# Altium schematic sheet MOS.SchDoc
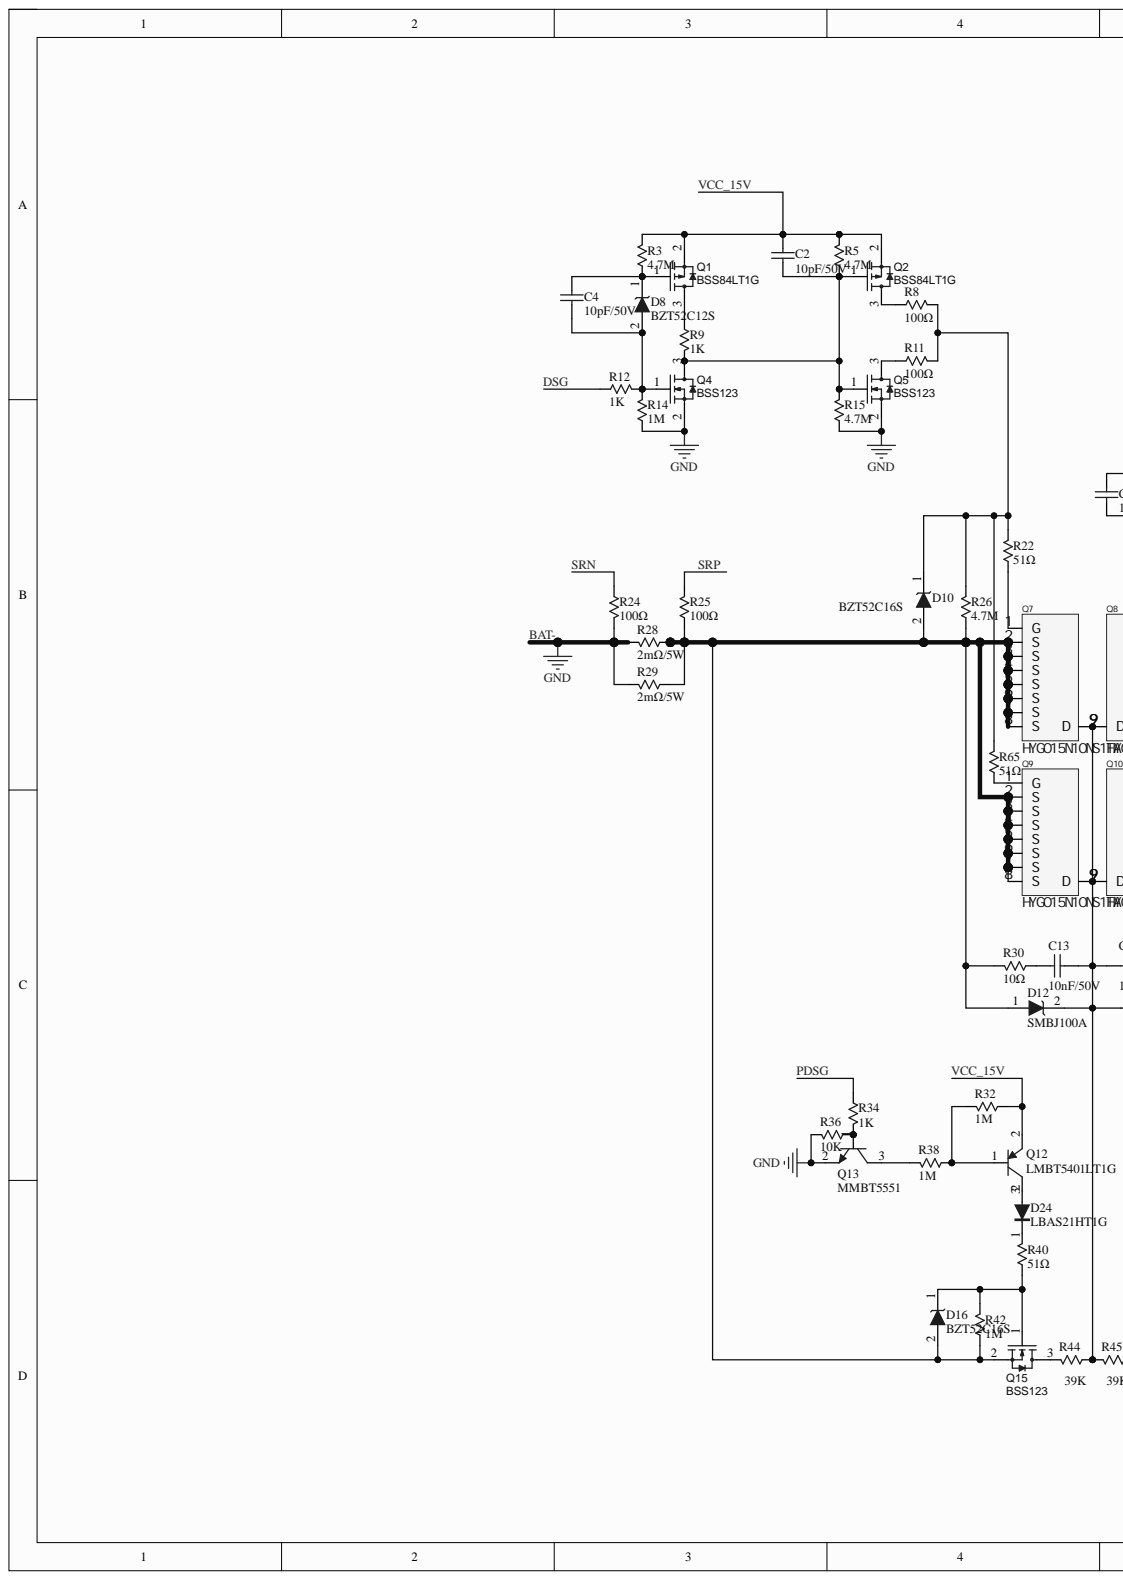
[diagram p0: MOS.SchDoc, left]
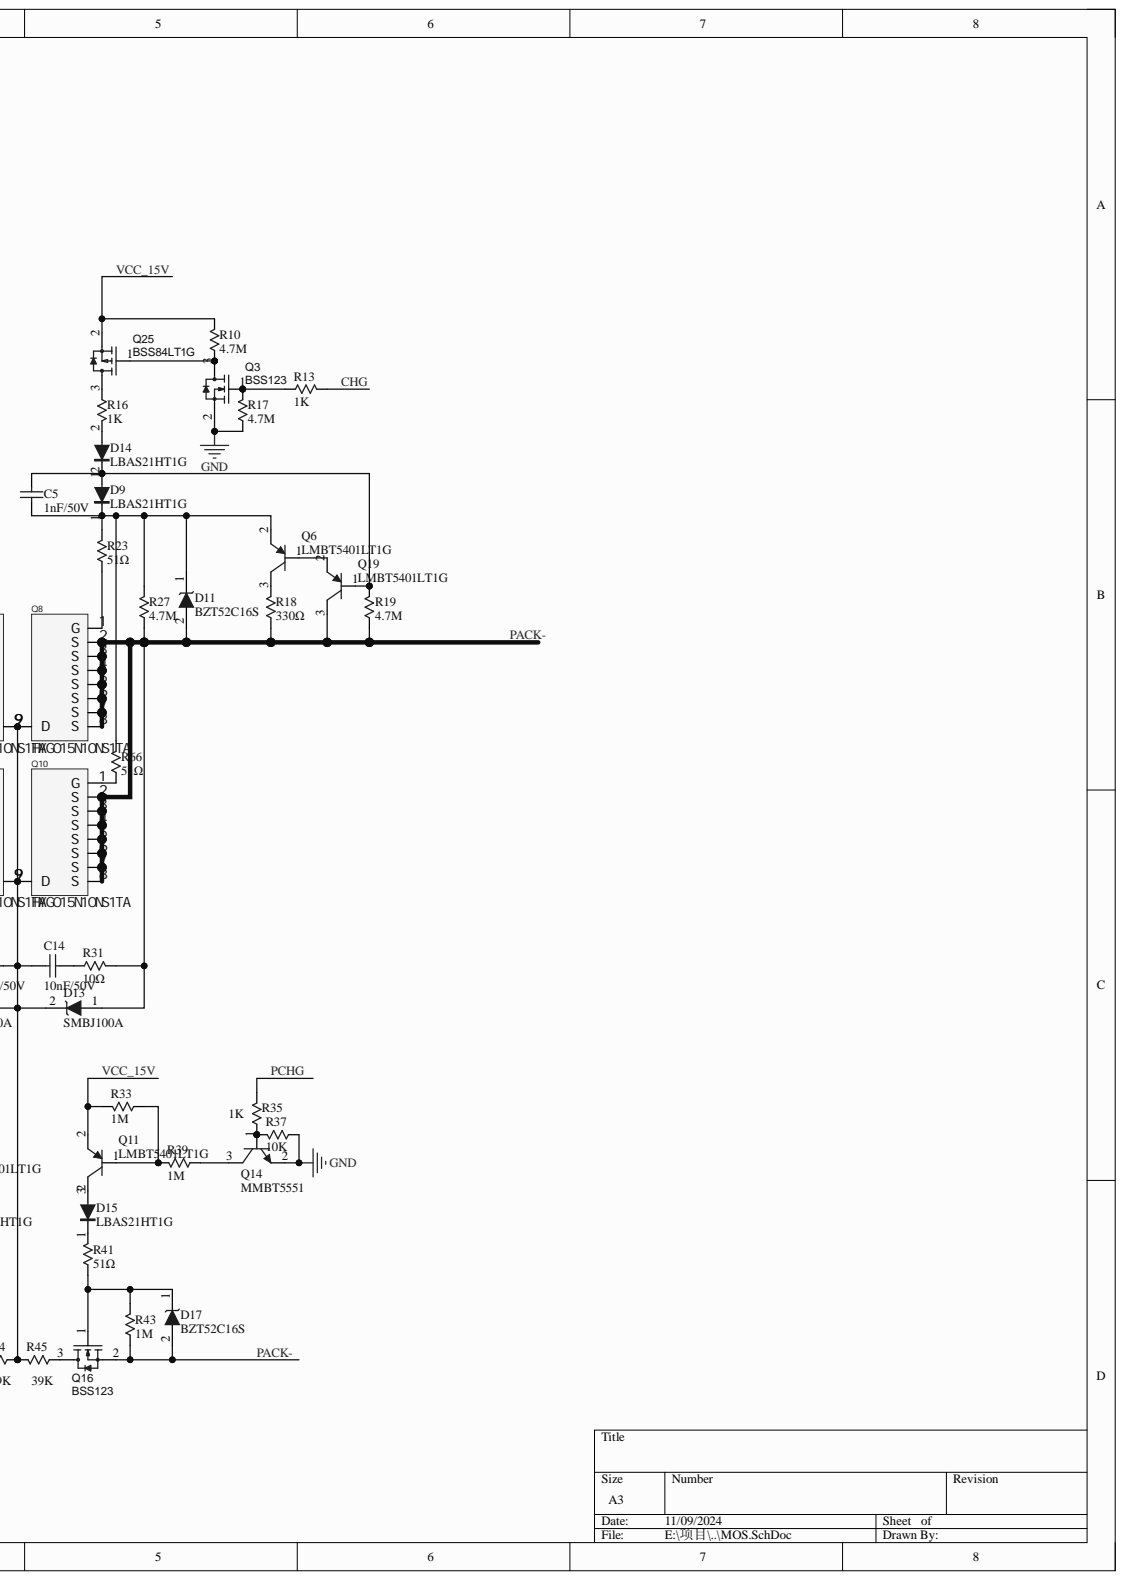
[diagram p1: MOS.SchDoc, right]

## Parts
C2  10pF/50V  [0603_C]
C4  10pF/50V  [0603_C]
C5  1nF/50V  [0603_C]
C13  10nF/50V  [0603_C]
C14  10nF/50V  [0603_C]
D8  BZT52C12S  [SOD-323]
D9  LBAS21HT1G  [SOD-323]
D10  BZT52C16S  [SOD-323]
D11  BZT52C16S  [SOD-323]
D12  SMBJ100A  [SMB/DO-214AA]
D13  SMBJ100A  [SMB/DO-214AA]
D14  LBAS21HT1G  [SOD-323]
D15  LBAS21HT1G  [SOD-323]
D16  BZT52C16S  [SOD-323]
D17  BZT52C16S  [SOD-323]
D24  LBAS21HT1G  [SOD-323]
Q1  BSS84LT1G  [SOT-23-3]
Q2  BSS84LT1G  [SOT-23-3]
Q3  BSS123  [SOT-23-3]
Q4  BSS123  [SOT-23-3]
Q5  BSS123  [SOT-23-3]
Q6  LMBT5401LT1G  [SOT-23-3]
Q7  HYG015N10NS1TA  [HSOF-8_L10.0-W10.5-P1.20]
Q8  HYG015N10NS1TA  [HSOF-8_L10.0-W10.5-P1.20]
Q9  HYG015N10NS1TA  [HSOF-8_L10.0-W10.5-P1.20]
Q10  HYG015N10NS1TA  [HSOF-8_L10.0-W10.5-P1.20]
Q11  LMBT5401LT1G  [SOT-23-3]
Q12  LMBT5401LT1G  [SOT-23-3]
Q13  MMBT5551  [SOT-23-3]
Q14  MMBT5551  [SOT-23-3]
Q15  BSS123  [SOT-23-3]
Q16  BSS123  [SOT-23-3]
Q19  LMBT5401LT1G  [SOT-23-3]
Q25  BSS84LT1G  [SOT-23-3]
R3  4.7M  [0603_R]
R5  4.7M  [0603_R]
R8  100Ω  [0603_R]
R9  1K  [0603_R]
R10  4.7M  [0603_R]
R11  100Ω  [0603_R]
R12  1K  [0603_R]
R13  1K  [0603_R]
R14  1M  [0603_R]
R15  4.7M  [0603_R]
R16  1K  [0603_R]
R17  4.7M  [0603_R]
R18  330Ω  [0603_R]
R19  4.7M  [0603_R]
R22  51Ω  [0603_R]
R23  51Ω  [0603_R]
R24  100Ω  [0603_R]
R25  100Ω  [0603_R]
R26  4.7M  [0603_R]
R27  4.7M  [0603_R]
R28  2mΩ/5W  [2512_R]
R29  2mΩ/5W  [2512_R]
R30  10Ω  [0603_R]
R31  10Ω  [0603_R]
R32  1M  [0603_R]
R33  1M  [0603_R]
R34  1K  [0603_R]
R35  1K  [0603_R]
R36  10K  [0603_R]
R37  10K  [0603_R]
R38  1M  [0603_R]
R39  1M  [0603_R]
R40  51Ω  [0603_R]
R41  51Ω  [0603_R]
R42  1M  [0603_R]
R43  1M  [0603_R]
R44  39K  [0603_R]
R45  39K  [0603_R]
R65  51Ω  [0603_R]
R66  51Ω  [0603_R]

## Nets
BAT-: Q3.2 Q4.2 Q5.2 Q13.2 Q14.2 R14.1 R15.1 R17.1 R24.1 R28.2 R29.2 R36.1 R37.2
CHG: R13.2
DSG: R12.1
PACK-: D11.2 D13.1 D17.2 Q8.2(S) Q8.3(S) Q8.4(S) Q8.5(S) Q8.6(S) Q8.7(S) Q8.8(S) Q10.2(S) Q10.3(S) Q10.4(S) Q10.5(S) Q10.6(S) Q10.7(S) Q10.8(S) Q16.2 Q19.3 R18.1 R19.1 R27.1 R31.1 R43.1
PCHG: R35.1
PDSG: R34.1
SRN: R24.2
SRP: R25.1
VCC_15V: C2.1 Q1.2 Q2.2 Q11.2 Q12.2 Q25.2 R3.2 R5.2 R10.2 R32.2 R33.2
NetC2_2: C2.2 Q2.1 Q4.3 Q5.1 R5.1 R9.2 R15.2
NetC4_1: C4.1 D8.1 Q1.1 R3.1
NetC4_2: C4.2 D8.2 Q4.1 R12.2 R14.2
NetC5_1: C5.1 D9.2 D14.1 Q19.1 R19.2
NetC5_2: C5.2 D9.1 D11.1 Q6.2 R23.1 R27.2 R66.1
NetC13_1: C13.1 R30.2
NetC13_2: C13.2 C14.2 D12.2 D13.2 Q7.9(D) Q8.9(D) Q9.9(D) Q10.9(D) R44.2 R45.1
NetC14_1: C14.1 R31.2
NetD10_1: D10.1 R8.2 R11.2 R22.1 R26.2 R65.1
NetD10_2: D10.2 D12.1 D16.2 Q7.2(S) Q7.3(S) Q7.4(S) Q7.5(S) Q7.6(S) Q7.7(S) Q7.8(S) Q9.2(S) Q9.3(S) Q9.4(S) Q9.5(S) Q9.6(S) Q9.7(S) Q9.8(S) Q15.2 R25.2 R26.1 R28.1 R29.1 R30.1 R42.1
NetD14_2: D14.2 R16.2
NetD15_1: D15.1 R41.1
NetD15_2: D15.2 Q11.3
NetD16_1: D16.1 Q15.1 R40.2 R42.2
NetD17_1: D17.1 Q16.1 R41.2 R43.2
NetD24_1: D24.1 R40.1
NetD24_2: D24.2 Q12.3
NetQ1_3: Q1.3 R9.1
NetQ2_3: Q2.3 R8.1
NetQ3_1: Q3.1 R13.1 R17.2
NetQ3_3: Q3.3 Q25.1 R10.1
NetQ5_3: Q5.3 R11.1
NetQ6_1: Q6.1 Q19.2
NetQ6_3: Q6.3 R18.2
NetQ7_1: Q7.1(G) R22.2
NetQ8_1: Q8.1(G) R23.2
NetQ9_1: Q9.1(G) R65.2
NetQ10_1: Q10.1(G) R66.2
NetQ11_1: Q11.1 R33.1 R39.2
NetQ12_1: Q12.1 R32.1 R38.2
NetQ13_1: Q13.1 R34.2 R36.2
NetQ13_3: Q13.3 R38.1
NetQ14_1: Q14.1 R35.2 R37.1
NetQ14_3: Q14.3 R39.1
NetQ15_3: Q15.3 R44.1
NetQ16_3: Q16.3 R45.2
NetQ25_3: Q25.3 R16.1

## Library data of the parts
C2 C4 C5 C13 C14 = Cap: Capacitor; Component Kind: Standard; LatestRevisionDate: 17-Jul-2002; LatestRevisionNote: Re-released for DXP Platform.; PackageReference: RAD-0.3; Published: 8-Jun-2000; Signal Integrity: Cap; Simulation: CAP; Library Name: UP-ROBOT Devices.SchLib; Publisher: Altium Limited
  C2 C4: Value: 10pF/50V
  C5: Value: 1nF/50V
  C13 C14: Value: 10nF/50V
D8 = BZT52C16S: Component Kind: Standard; Library Name: DVC1124_20S.SchLib
D9 D14 D15 D24 = 1N4148W: Component Kind: Standard; Library Name: DVC1124_20S.SchLib
Q1 Q2 Q25 = PMOS: BOM_Manufacturer: Ncepower; BOM_Manufacturer Part: NCE0102; Contributor: LCSC; BOM_JLCPCB Part Class: Extended Part; BOM_Supplier: LCSC; BOM_Supplier Part: C161843; Component Kind: Standard; Library Name: BMS_20S_MCU_MOS.SchLib; spicePre: Q; spiceSymbolName: NCE0102
Q3-Q5 Q15 Q16 = BSS131: BOM_Manufacturer: Ncepower; BOM_Manufacturer Part: NCE0102; Contributor: LCSC; BOM_JLCPCB Part Class: Extended Part; BOM_Supplier: LCSC; BOM_Supplier Part: C161843; Component Kind: Standard; Library Name: DVC1124_20S.SchLib; spicePre: Q; spiceSymbolName: NCE0102
Q6 Q11 Q12 Q19 = PNP: Component Kind: Standard; Library Name: Three_Axis_Arm_Control_V1.1.SchLib
Q7-Q10 = NCEP023N10LL: Manufacturer: NCE(无锡新洁能); Add into BOM: yes; Component Kind: Standard; Convert to PCB: yes; Datasheet: https://atta.szlcsc.com/upload/public/pdf/source/20211028/8952B228EFC24217B65A664BEBCD3BF5.pdf; Device: NCEP023N10LL; JLCPCB Part Class: Extended Part; LCSC Part Name: NCEP023N10LL; Library Name: DVC1124_20S.SchLib; Manufacturer Part: NCEP023N10LL; Name: NCEP023N10LL; Supplier: LCSC; Supplier Footprint: TOLL; Supplier Part: C2686686; Symbol: NCEP023N10LL
Q13 Q14 = NPN: Component Kind: Standard; Library Name: Three_Axis_Arm_Control_V1.1.SchLib
R3 R5 R8-R19 R22-R45 R65 R66 = Res: Resistor; Component Kind: Standard; LatestRevisionDate: 17-Jul-2002; LatestRevisionNote: Re-released for DXP Platform.; PackageReference: AXIAL-0.3; Published: 8-Jun-2000; Signal Integrity: Res; Simulation: RESISTOR; Library Name: UP-ROBOT Devices.SchLib; Publisher: Altium Limited
  R3 R5 R10 R15 R17 R19 R26 R27: Value: 4.7M
  R8 R11 R24 R25: Value: 100Ω
  R9 R12 R13 R16 R34 R35: Value: 1K
  R14 R32 R33 R38 R39 R42 R43: Value: 1M
  R18: Value: 330Ω
  R22 R23 R40 R41 R65 R66: Value: 51Ω
  R28 R29: Value: 2mΩ/5W
  R30 R31: Value: 10Ω
  R36 R37: Value: 10K
  R44 R45: Value: 39K
D10 D11 D16 D17 = BZT52C16S: Component Kind: Standard; Library Name: DVC1124_20S.SchLib
D12 D13 = SMBJ100A: Component Kind: Standard; Library Name: DVC1124_20S.SchLib
